# Altium schematic sheet DOut_Relay.SchDoc
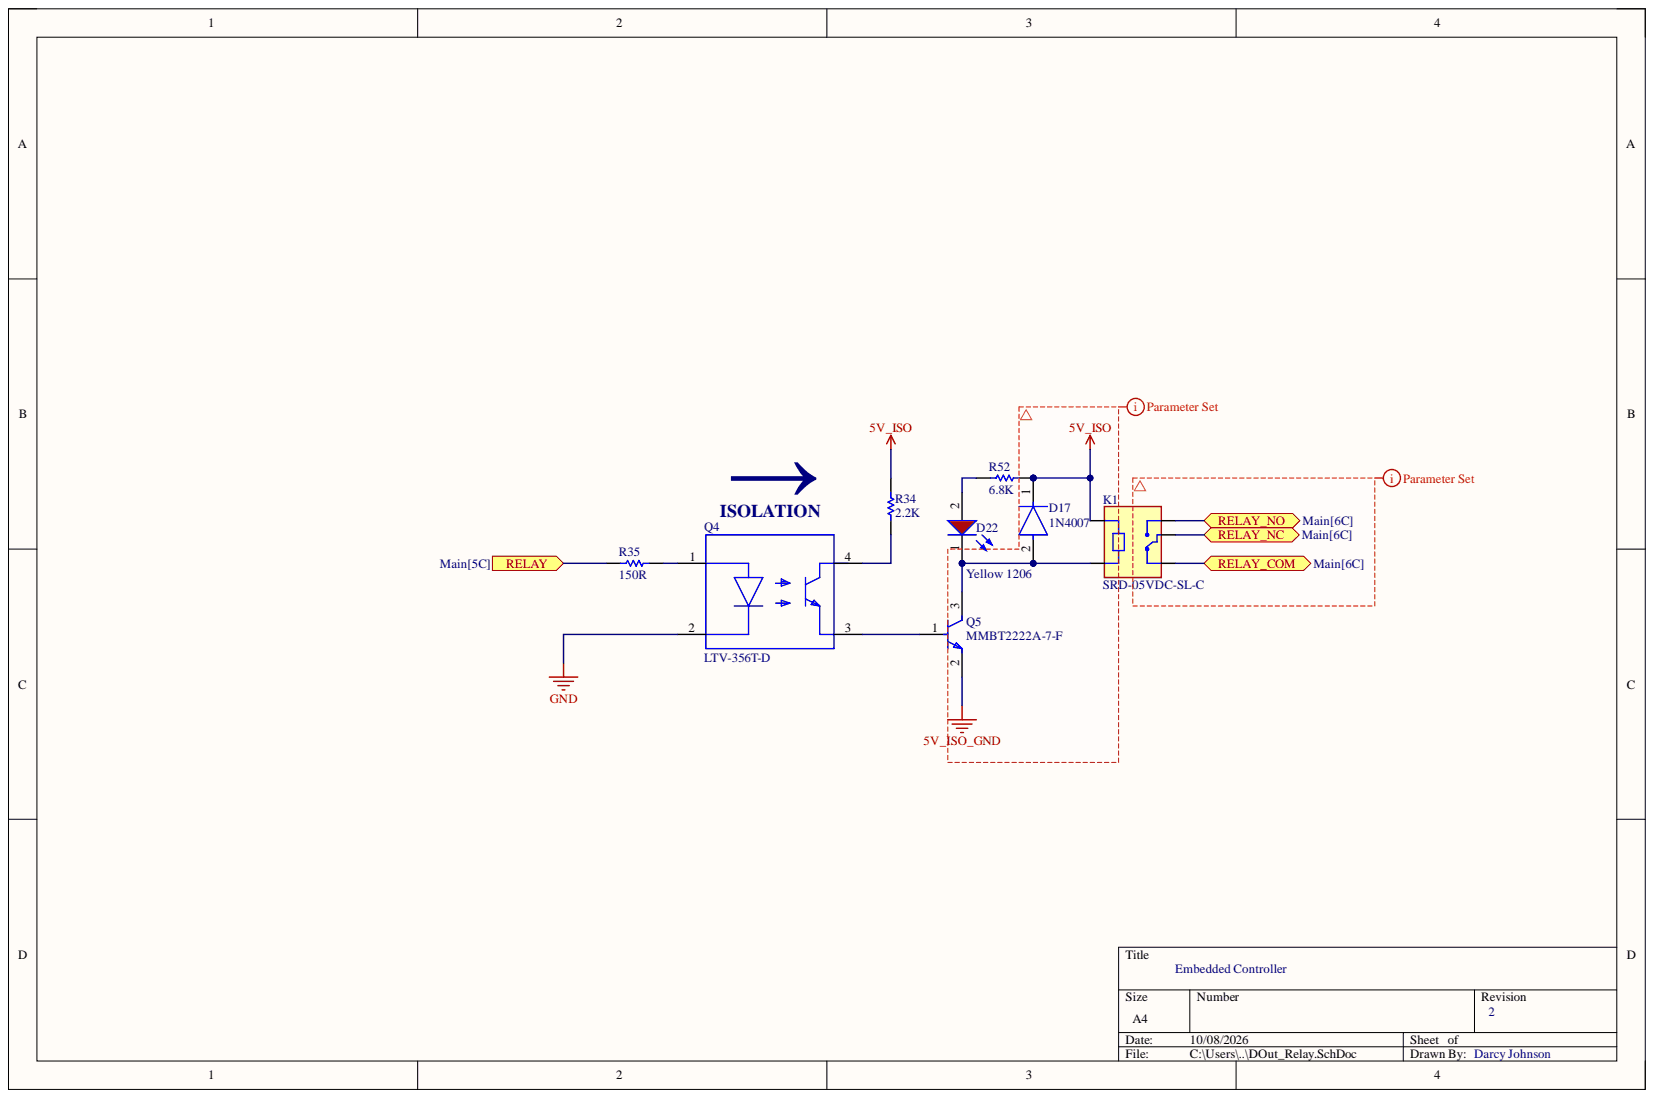
[diagram p0: DOut_Relay.SchDoc]

## Parts
D17  1N4007  [FP-1N4007_SMD-IPC_C]
D22  Yellow 1206  [WL-SMCW_1206_150120xx75000]
K1  SRD-05VDC-SL-C  [RELAY_SRD-05VDC-SL-C]
Q4  LTV-356T-D  [FP-LTV-356T-D-MFG]
Q5  MMBT2222A-7-F  [FP-SOT23-IPC_A]
R34  2.2K  [RESC1608X55X30LL15T15]
R35  150R  [RESC1608X55X30ML20T20]
R52  6.8K  [RESC1608X55X30LL15T15]

## Ports
RELAY, RELAY_COM, RELAY_NC, RELAY_NO

## Nets
5V_ISO: D17.1 K1.A1 R34.1 R52.2
5V_ISO_GND: Q5.2
GND: Q4.2
RELAY: R35.1 ; port RELAY
RELAY_COM: K1.NC ; port RELAY_COM
RELAY_NC: K1.COM ; port RELAY_NC
RELAY_NO: K1.NO ; port RELAY_NO
NetD17_2: D17.2 D22.1 K1.A2 Q5.3
NetD22_2: D22.2 R52.1
NetQ4_1: Q4.1 R35.2
NetQ4_3: Q4.3 Q5.1
NetQ4_4: Q4.4 R34.2

## Library data of the parts
D17 = CMP-12389-000001-1: Rectifier, 1Kv, 1A, Do-214Ac Rohs Compliant: Yes; Component Kind: Standard; Library Name: Altium Content Vault
D22 = CMP-1426-00017-3: Led, Red, 630 Nm, 1.9 V, 30 Ma, 60 Mcd Rohs Compliant: Yes; Dominant Wavelength: 630nm; Forward Current: 100mA; Forward Voltage: 1.9V; Lens Style: Clear, Rectangular; Luminous Intensity: 60mcd; Max Reverse Voltage (DC): 5V; Peak Wavelength: 645nm; Test Current: 20mA; Viewing Angle: 140°; Case Code (Imperial): 1206; Case Code (Metric): 3216; Case/Package: 1206; Color: Red; Component Kind: Standard; Height: 680µm; Length: 3.2mm; Library Name: Altium Content Vault; Max Operating Temperature: 85°C; Min Operating Temperature: -40°C; Mount: Surface Mount; Packaging: Tape and Reel; REACH SVHC: Unknown; RoHS: Compliant; Wavelength: 630nm; Width: 1.6mm
K1 = SRD-05VDC-SL-C: 5V Trigger Relay Module For Arduino And Raspberry Pi 5V Trigger Relay Module For Arduino And Raspberry Pi; MF: Songle Relay; Availability: Not in stock; Check_prices: https://www.snapeda.com/parts/SRD-05VDC-SL-C/Songle+Relay/view-part/?ref=eda; Component Kind: Standard; Library Name: SRD-05VDC-SL-C.IntLib; MP: SRD-05VDC-SL-C; Package: NON STANDARD-5 Songle Relay; Price: None; SnapEDA_Link: https://www.snapeda.com/parts/SRD-05VDC-SL-C/Songle+Relay/view-part/?ref=snap
Q4 = CMP-22018-000003-1: OPTOCPL 1 CH TRAN OUT SMT; Manufacturer: Lite-On; Collector Emitter Voltage: 80V; Emiiter Collector Voltage: 6V; Forward Current: 20mA; Forward Voltage: 1.2V; Isolation Voltage: 3750Vrms; Max Current Transfer Ratio: 600%; Max Junction Temp: +125°C; Min Current Transfer Ratio: 300%; Power Dissipation: 170mW; Standoff Height: 0mm; Automotive: No; Category: Trans; Component Kind: Standard; ComponentLink2Description: Datasheet; ComponentLink2URL: https://ve.mouser.com/datasheet/2/239/LTV-356T%20series%20201610-1139805.pdf; Device Class L1: Optoelectronics; Device Class L2: Optocouplers; Device Class L3: unset; Digikey Description: OPTOCPL 1 CH TRAN OUT SMT; Height: 2.4mm; Lead Free: Yes; Library Name: Altium Content Vault; Manufacturer Part Number: LTV-356T-D; Octopart: https://octopart.com/ltv-356t-d-lite-on-11764243; Package: LTV-356T-D; Reverse Voltage: 6V; RoHS: TRUE; Temperature: -55°C to +110°C; Temperature Range High: +110°C; Temperature Range Low: -55°C
Q5 = CMP-1693-00003-4: TRANS NPN 40V 0.6A SMD SOT23-3; Collector Base Voltage (VCBO): 75V; Collector Emitter Saturation Voltage: 1V; Continuous Collector Current: 600mA; Current: 1A; Element Configuration: Single; Emitter Base Voltage (VEBO): 6V; Frequency: 300MHz; hFE Min: 100; Max Power Dissipation: 300mW; Number of Elements: 1; Power Dissipation: 350mW; Case/Package: SOT-23; Collector Emitter Breakdown Voltage: 40V; Collector Emitter Voltage (VCEO): 40V; Component Kind: Standard; Contact Plating: Tin; Current Rating: 600mA; Gain Bandwidth Product: 300MHz; Height: 1mm; Lead Free: Lead Free; Length: 3.05mm; Library Name: Altium Content Vault; Max Breakdown Voltage: 40V; Max Collector Current: 600mA; Max Frequency: 300MHz; Max Operating Temperature: 150°C; Min Operating Temperature: -55°C; Mount: Surface Mount; Number of Pins: 3; Package Quantity: 3000; Packaging: Tape and Reel; Polarity: NPN; Radiation Hardening: No; REACH SVHC: No SVHC; Termination: SMD/SMT; Transition Frequency: 300MHz; Voltage: 40V; Voltage Rating (DC): 40V; Weight: 0.000282oz; Width: 1.4mm
R34 = CMP-2000-00336-1: Manufacturer: Panasonic; Part Number: ERJ3EKF2201V; Component Kind: Standard; Library Name: Altium Content Vault
R35 = CMP-2000-00914-1: Manufacturer: Panasonic; Part Number: ERA3AEB151V; Component Kind: Standard; Library Name: Altium Content Vault
R52 = CMP-2000-00803-1: Manufacturer: Panasonic; Part Number: ERJ-3GEYJ512V; Component Kind: Standard; Library Name: Altium Content Vault
